# Altium schematic sheet Block_Diagram.SchDoc
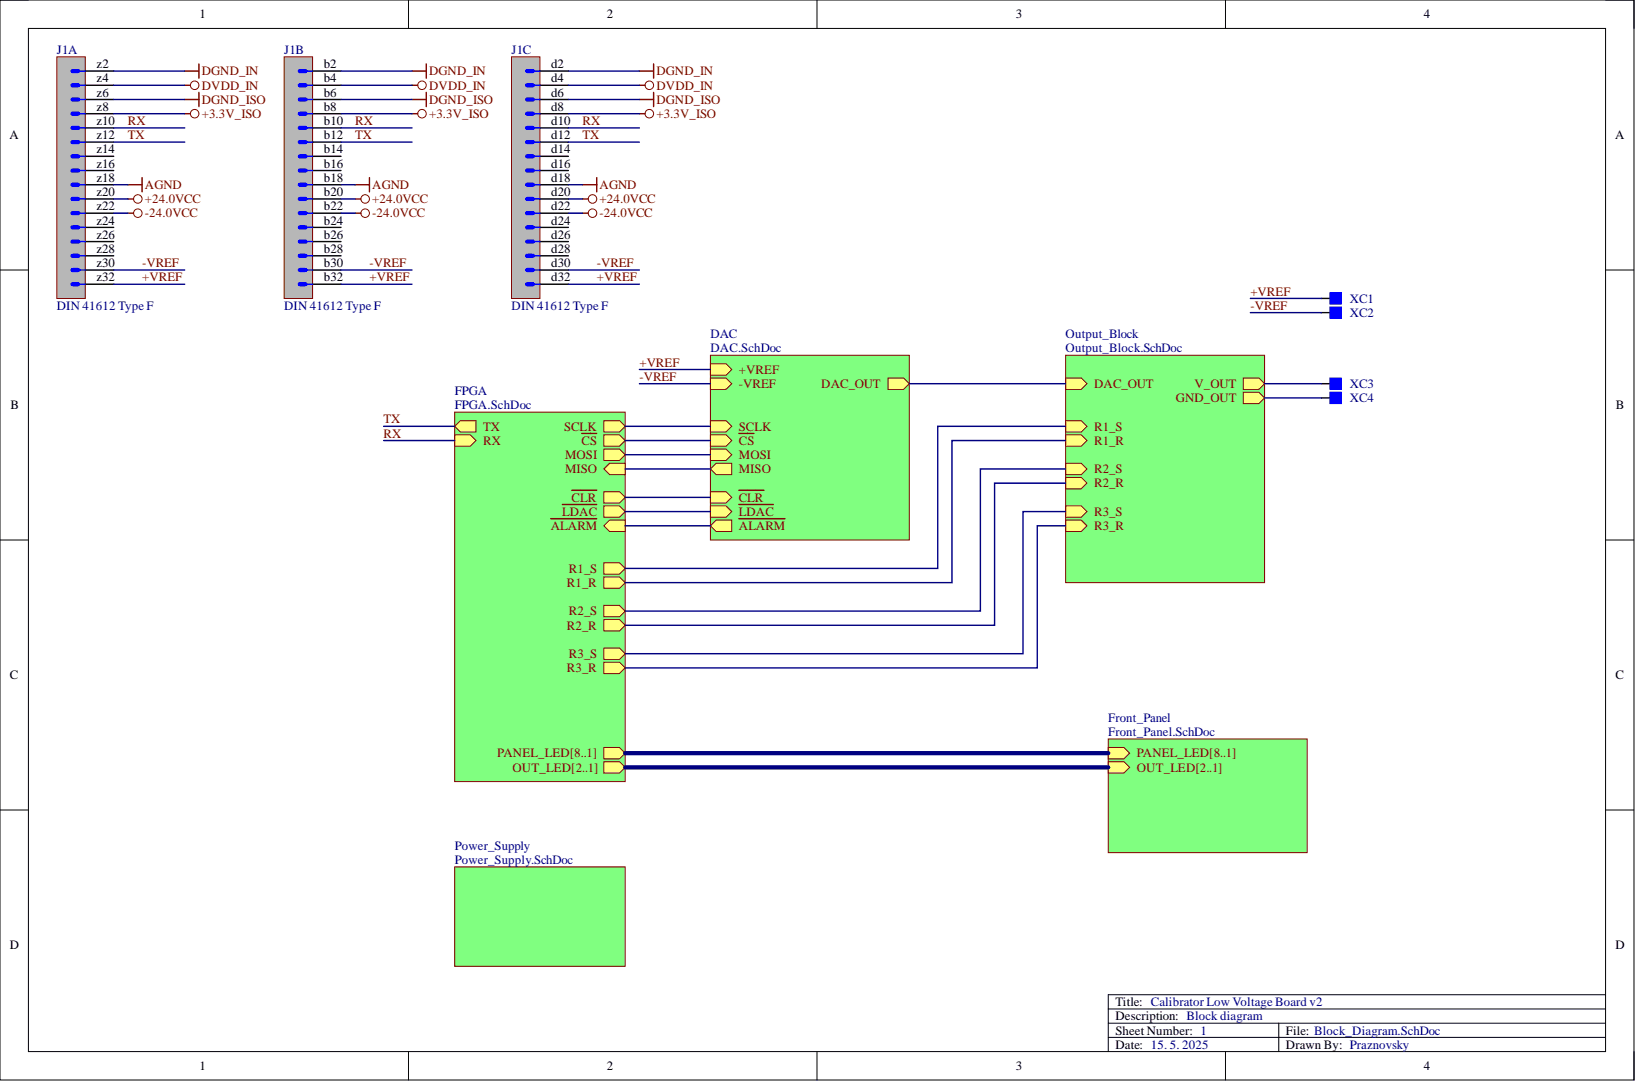
[diagram p0: Block_Diagram.SchDoc]

## Parts
J1  DIN 41612 Type F  [HARTING 09 06 148 6901]  (parts A,B,C)
XC1  Solder Pad 4x4 mm  [Solder Pad 4x4 mm]  (value not drawn)  (no BOM)
XC2  Solder Pad 4x4 mm  [Solder Pad 4x4 mm]  (value not drawn)  (no BOM)
XC3  Solder Pad 4x4 mm  [Solder Pad 4x4 mm]  (value not drawn)  (no BOM)
XC4  Solder Pad 4x4 mm  [Solder Pad 4x4 mm]  (value not drawn)  (no BOM)

## Nets
+3.3V_ISO: J1.b8 J1.d8 J1.z8
+24.0VCC: J1.b20 J1.d20 J1.z20
-24.0VCC: J1.b22 J1.d22 J1.z22
AGND: J1.b18 J1.d18 J1.z18
DGND_IN: J1.b2 J1.d2 J1.z2
DGND_ISO: J1.b6 J1.d6 J1.z6
DVDD_IN: J1.b4 J1.d4 J1.z4
+VREF: J1.b32 J1.d32 J1.z32 XC1.1
-VREF: J1.b30 J1.d30 J1.z30 XC2.1
RX: J1.b10 J1.d10 J1.z10
TX: J1.b12 J1.d12 J1.z12
NetJ1_b14: J1.b14
NetJ1_b16: J1.b16
NetJ1_b24: J1.b24
NetJ1_b26: J1.b26
NetJ1_b28: J1.b28
NetJ1_d14: J1.d14
NetJ1_d16: J1.d16
NetJ1_d24: J1.d24
NetJ1_d26: J1.d26
NetJ1_d28: J1.d28
NetJ1_z14: J1.z14
NetJ1_z16: J1.z16
NetJ1_z24: J1.z24
NetJ1_z26: J1.z26
NetJ1_z28: J1.z28
NetXC3_1: XC3.1
NetXC4_1: XC4.1
~{ALARM}:
~{CLR}:
~{CS}:
DAC_OUT:
~{LDAC}:
MISO:
MOSI:
OUT_LED1:
OUT_LED2:
PANEL_LED1:
PANEL_LED2:
PANEL_LED3:
PANEL_LED4:
PANEL_LED5:
PANEL_LED6:
PANEL_LED7:
PANEL_LED8:
R1_R:
R1_S:
R2_R:
R2_S:
R3_R:
R3_S:
SCLK:

## Library data of the parts
J1 = CMP-00016-21: DIN 41612, Type F, 48 Contacts, Rows z+b+d, Performance level 2, Right Angle Male Connector; Component Kind: Standard; Library Name: Calibrator
XC1-XC4 = CMP-00082-1: Description: Solder Pad 4x4 mm; Library Name: Calibrator
